# Altium schematic sheet Sheet_2_Power.SchDoc(Sheet_2_Power)
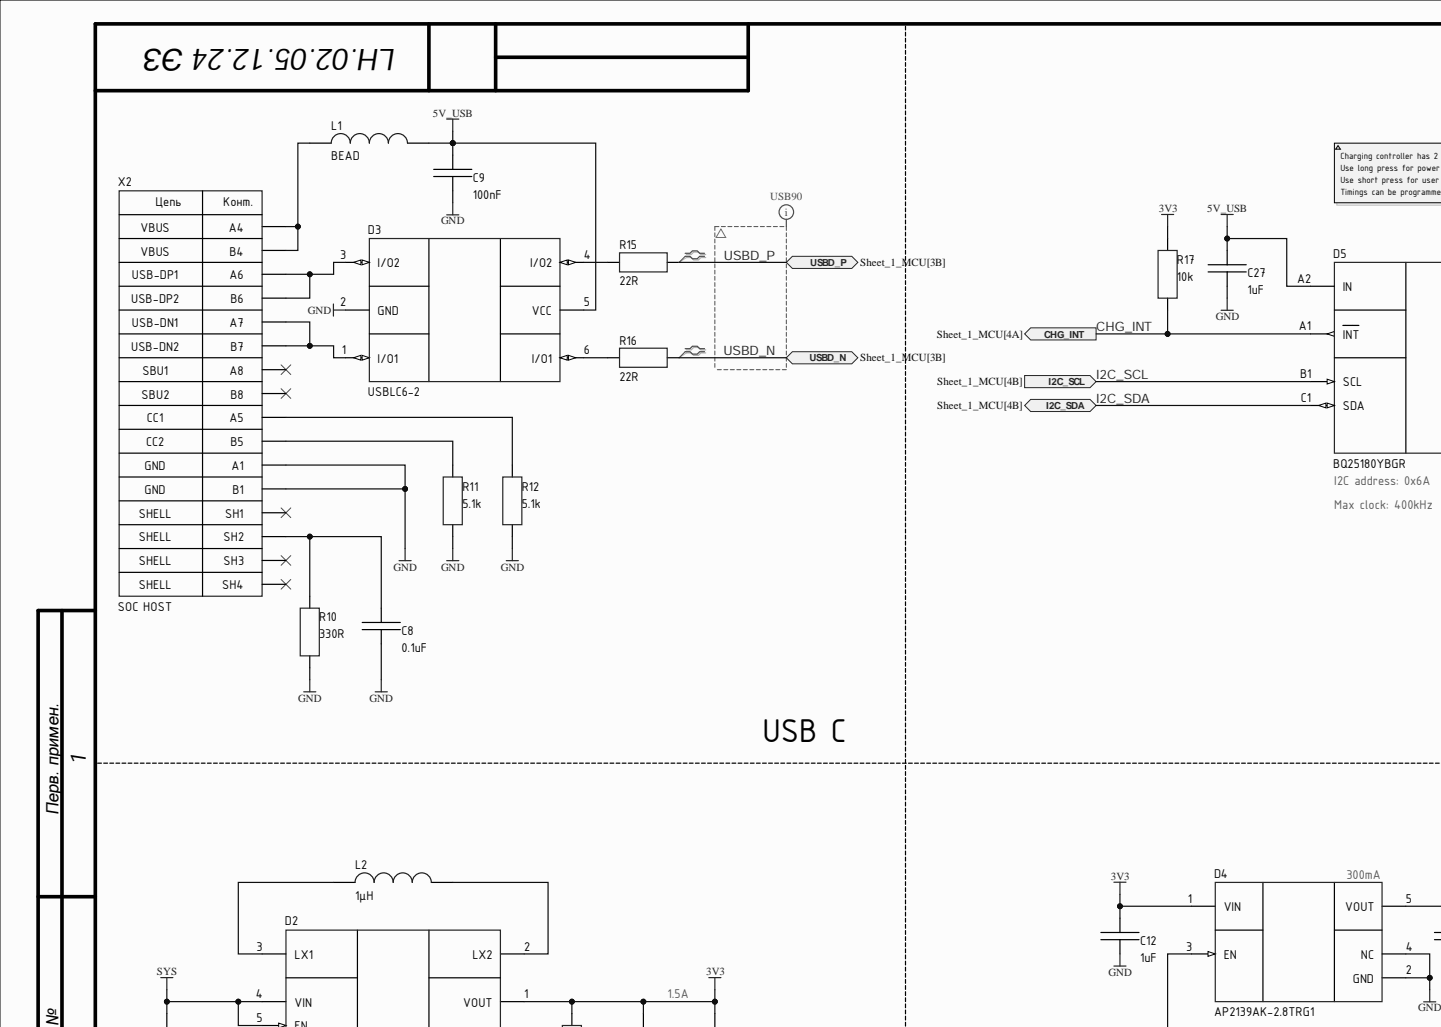
[diagram p0: Sheet_2_Power.SchDoc, top left]
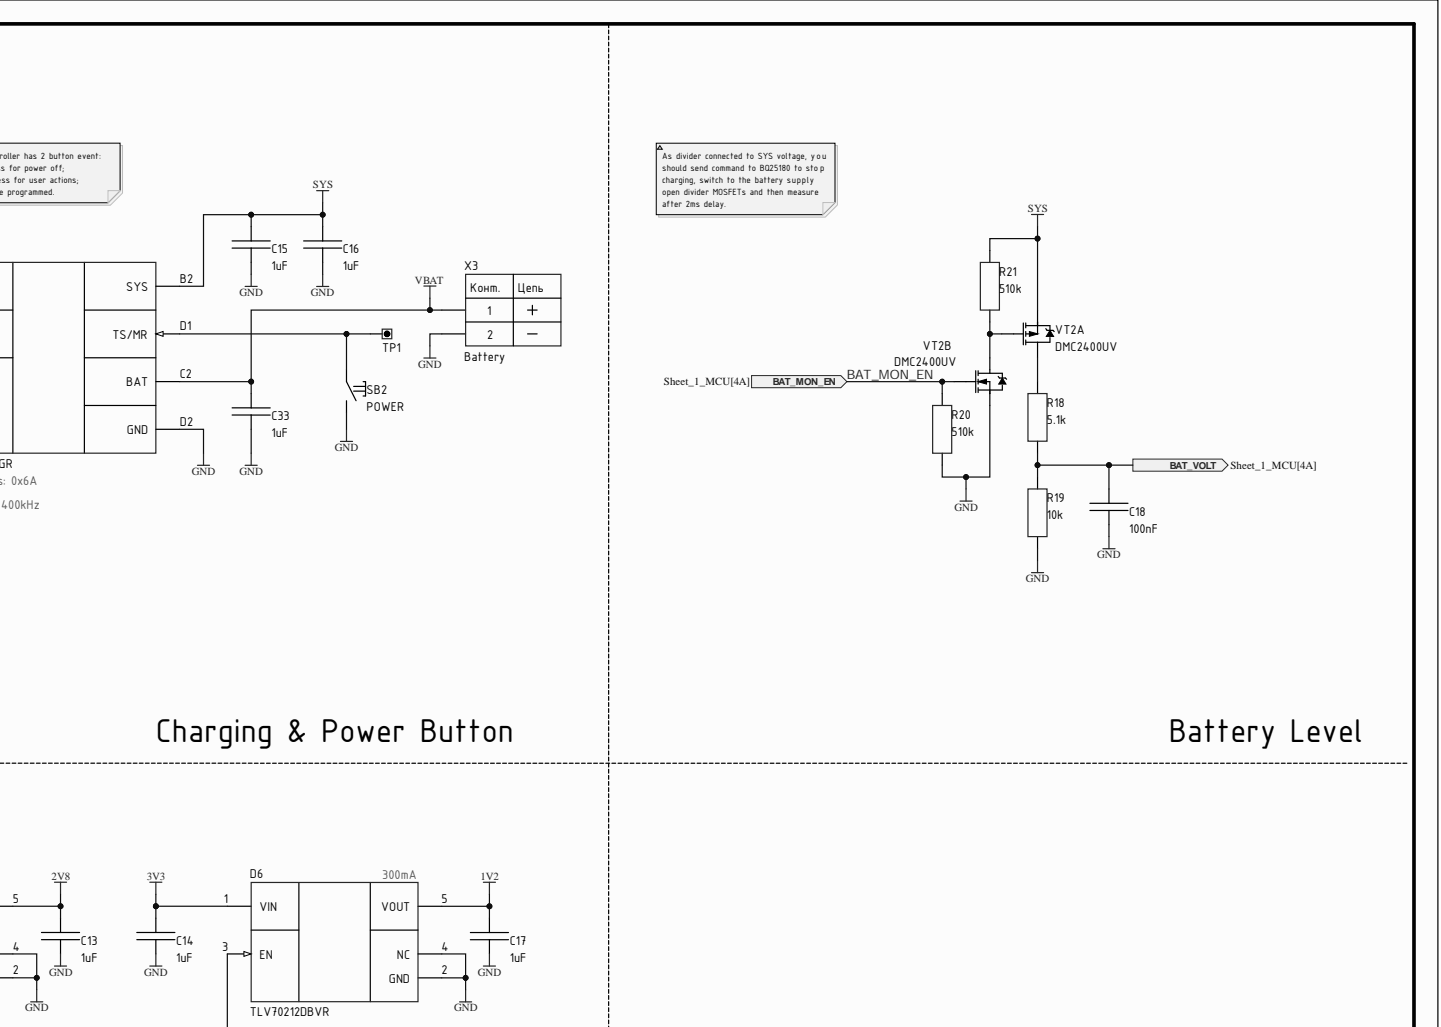
[diagram p1: Sheet_2_Power.SchDoc, top right]
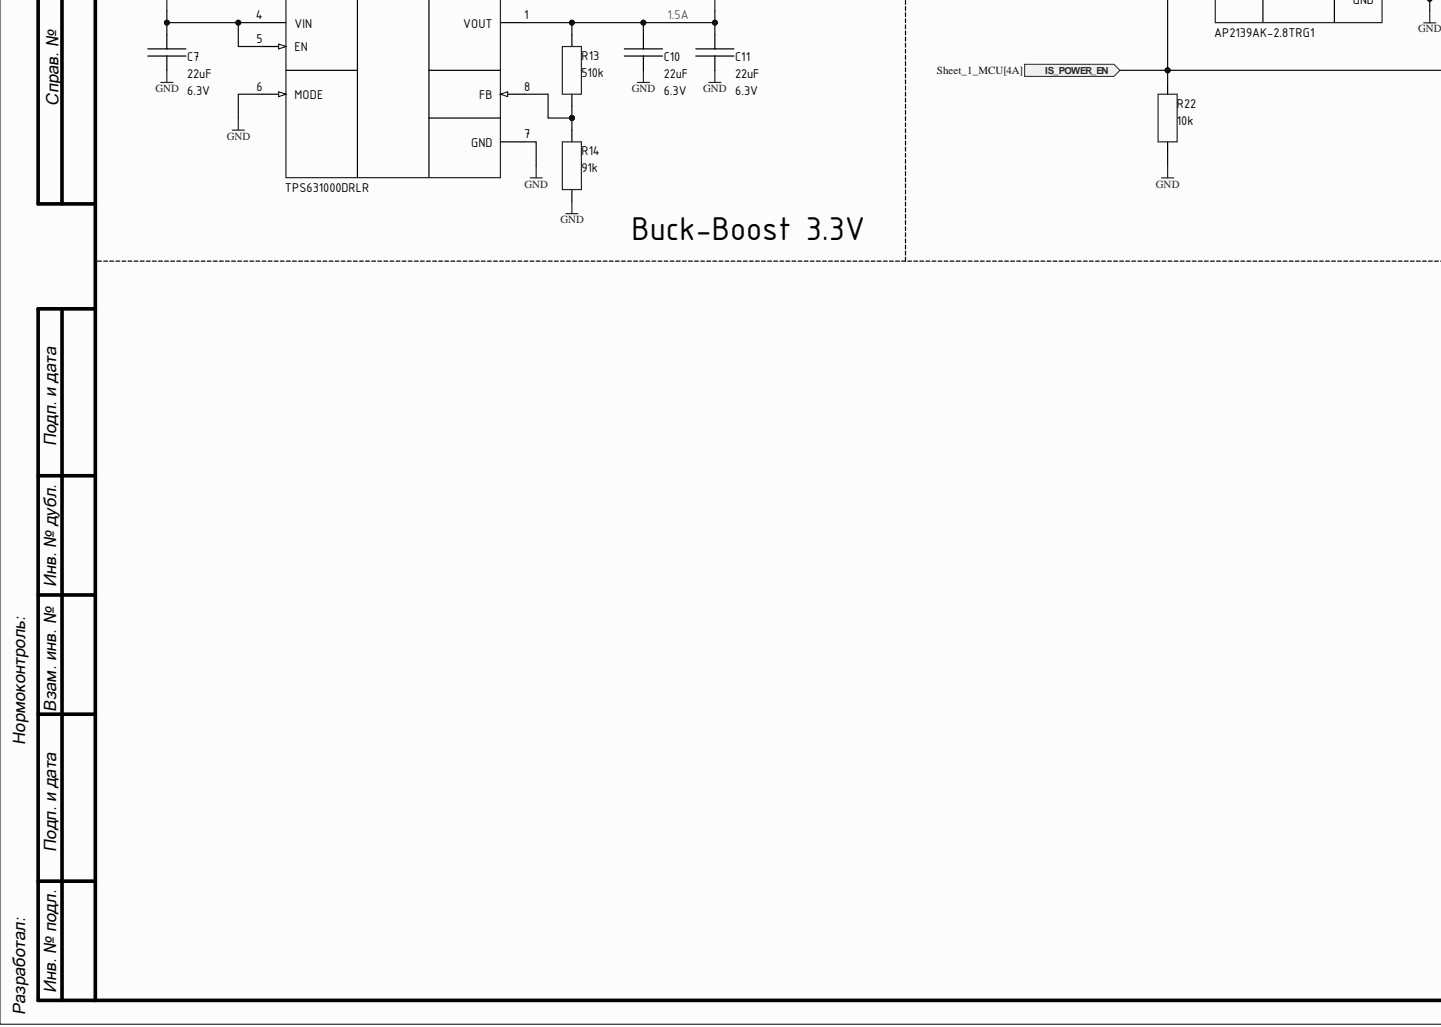
[diagram p2: Sheet_2_Power.SchDoc, bottom left]
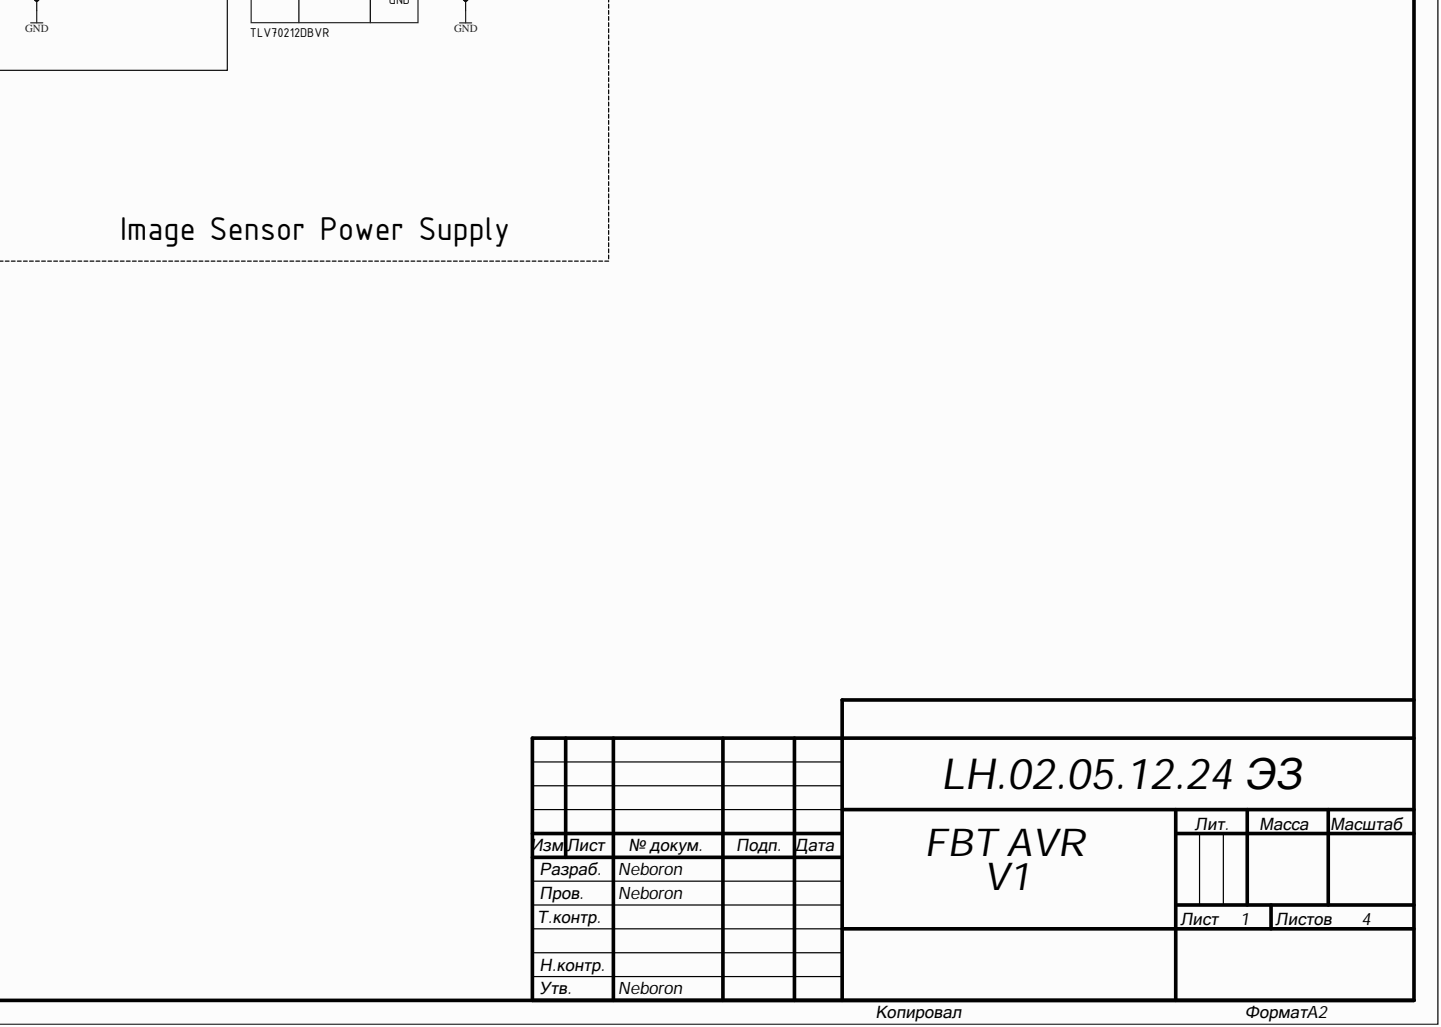
[diagram p3: Sheet_2_Power.SchDoc, bottom right]

## Parts
C7  value 22uF  Voltage: 6.3V  [CAP: 0603]  (hidden comment: Ceramic capacitor, 22uF, X5R, ±10%, 6.3V, 0603, -55...+125°C)
C8  value 0.1uF  [CAP: 0603]  (hidden comment: Ceramic capacitor, 0.1uF, X7R, ±10%, 16V, 0603, -55...+125°C)
C9  value 100nF  [CAP: 0402]  (hidden comment: Ceramic capacitor, 100nF, X7R, ±10%, 16V, 0402, -55...+125°C)
C10  value 22uF  Voltage: 6.3V  [CAP: 0603]  (hidden comment: Ceramic capacitor, 22uF, X5R, ±10%, 6.3V, 0603, -55...+125°C)
C11  value 22uF  Voltage: 6.3V  [CAP: 0603]  (hidden comment: Ceramic capacitor, 22uF, X5R, ±10%, 6.3V, 0603, -55...+125°C)
C12  value 1uF  [CAP: 0402]  (hidden comment: Ceramic capacitor, 1uF, X7R, ±10%, 16V, 0402, -55...+125°C)
C13  value 1uF  [CAP: 0402]  (hidden comment: Ceramic capacitor, 1uF, X7R, ±10%, 16V, 0402, -55...+125°C)
C14  value 1uF  [CAP: 0402]  (hidden comment: Ceramic capacitor, 1uF, X7R, ±10%, 16V, 0402, -55...+125°C)
C15  value 1uF  [CAP: 0402]  (hidden comment: Ceramic capacitor, 1uF, X7R, ±10%, 16V, 0402, -55...+125°C)
C16  value 1uF  [CAP: 0402]  (hidden comment: Ceramic capacitor, 1uF, X7R, ±10%, 16V, 0402, -55...+125°C)
C17  value 1uF  [CAP: 0402]  (hidden comment: Ceramic capacitor, 1uF, X7R, ±10%, 16V, 0402, -55...+125°C)
C18  value 100nF  [CAP: 0402]  (hidden comment: Ceramic capacitor, 100nF, X7R, ±10%, 16V, 0402, -55...+125°C)
C27  value 1uF  [CAP: 0402]  (hidden comment: Ceramic capacitor, 1uF, X7R, ±10%, 16V, 0402, -55...+125°C)
C33  value 1uF  [CAP: 0402]  (hidden comment: Ceramic capacitor, 1uF, X7R, ±10%, 16V, 0402, -55...+125°C)
D2  DC/DC buck-boost converter, 1.6...5.5V, 2.4MHz, 1.2...5.3V, 1.5A, -40...+125°C, SOT-583  [SOT583-8]  (value not drawn)
D3  ESD protection, , 8kV, , -40...+125°C, SOT23-6L  [SOT23-6]  (value not drawn)
D4  Linear voltage regulator, 2.9...6V, 0.5V, 1.5V, 0.25A, -40...+85°C, SOT23-5  [SOT23-5]  (value not drawn)
D5  Charger li-ion battery, input 4.35...26V, , 1A, -40...+125°C, 8-DSBGA  [8-DSBGA]  (value not drawn)
D6  Linear voltage regulator, 2...5.5V, 0.26V, 1.2V, 0.3A, -40...+85°C, SOT23-5  [SOT23-5]  (value not drawn)
L1  value BEAD  [IND: 0402]  (hidden comment: Fixed inductor, BEAD, ±25%, 0402, 900mA, -55...+125°C)
L2  value 1μH  [IND: 0805]  (hidden comment: Fixed inductor, 1μH, ±20%, 0805, 2.2A, -55...+125°C)
R10  value 330R  [RES: 0603]  (hidden comment: Resistor film, 330R, ±5%, 0603, 0.1W, -55...+125°C)
R11  value 5.1k  [RES: 0402]  (hidden comment: Resistor film, 5.1k, ±5%, 0402, 0.063W, -55...+125°C)
R12  value 5.1k  [RES: 0402]  (hidden comment: Resistor film, 5.1k, ±5%, 0402, 0.063W, -55...+125°C)
R13  value 510k  [RES: 0402]  (hidden comment: Resistor film, 510k, ±5%, 0402, 0.063W, -55...+125°C)
R14  value 91k  [RES: 0402]  (hidden comment: Resistor film, 91k, ±5%, 0402, 0.063W, -55...+125°C)
R15  value 22R  [RES: 0402]  (hidden comment: Resistor film, 22R, ±5%, 0402, 0.063W, -55...+125°C)
R16  value 22R  [RES: 0402]  (hidden comment: Resistor film, 22R, ±5%, 0402, 0.063W, -55...+125°C)
R17  value 10k  [RES: 0402]  (hidden comment: Resistor film, 10k, ±5%, 0402, 0.063W, -55...+125°C)
R18  value 5.1k  [RES: 0402]  (hidden comment: Resistor film, 5.1k, ±5%, 0402, 0.063W, -55...+125°C)
R19  value 10k  [RES: 0402]  (hidden comment: Resistor film, 10k, ±5%, 0402, 0.063W, -55...+125°C)
R20  value 510k  [RES: 0402]  (hidden comment: Resistor film, 510k, ±5%, 0402, 0.063W, -55...+125°C)
R21  value 510k  [RES: 0402]  (hidden comment: Resistor film, 510k, ±5%, 0402, 0.063W, -55...+125°C)
R22  value 10k  [RES: 0402]  (hidden comment: Resistor film, 10k, ±5%, 0402, 0.063W, -55...+125°C)
SB2  CommentText: POWER  [BUT: TS-1186F-B-A]  (hidden comment: Tactile button, TS-1186F)
TP1  Test point, Keystone, 5001  [CON: TEST POINT 1 MM]  (value not drawn)
VT2  PartNumber: DMC2400UV  [SOT563-6]  (hidden comment: MOSFET Dula-channel transistor, 20V, 700mA, N-70pC, P-850pC, 1W, N-480mOhm, P-970mOhm, N-750pF, P-1000pF, SOT563, -55...+150°C)  (parts A,B)
X2  CommentText: SOC HOST  [CON: TYPE-C-SOFNG MC-311S]  (hidden comment: USB Type C, 5A)
X3  CommentText: Battery  [CON: BAT (2.00)]  (hidden comment: Connector, 2pin, 2mm, ,)

## Ports
BAT_MON_EN, BAT_VOLT, CHG_INT, I2C_SCL, I2C_SDA, IS_POWER_EN, USBD_N, USBD_P

## Nets
1V2: C17.1 D6.5(VOUT)
2V8: C13.1 D4.5(VOUT)
3V3: C10.2 C11.2 C12.1 C14.1 D2.1(VOUT) D4.1(VIN) D6.1(VIN) R13.2 R17.1
5V_USB: C9.1 C27.1 D3.5(VCC) D5.A2(IN) L1.1
GND: C7.1 C8.2 C9.2 C10.1 C11.1 C12.2 C13.2 C14.2 C15.2 C16.2 C17.2 C18.1 C27.2 C33.2 D2.6(MODE) D2.7(GND) D3.2(GND) D4.2(GND) D4.4(NC) D5.D2(GND) D6.2(GND) D6.4(NC) R10.1 R11.1 R12.1 R14.1 R19.1 R20.1 R22.2 SB2.2 VT2.1 X2.A1 X2.B1 X3.2
SYS: C7.2 C15.1 C16.1 D2.4(VIN) D2.5(EN) D5.B2(SYS) R21.2 VT2.4
VBAT: C33.1 D5.C2(BAT) X3.1
BAT_MON_EN: R20.2 VT2.2 ; port BAT_MON_EN
BAT_VOLT: C18.2 R18.1 R19.2 ; port BAT_VOLT
CHG_INT: D5.A1(INT) R17.2 ; port CHG_INT
I2C_SCL: D5.B1(SCL) ; port I2C_SCL
I2C_SDA: D5.C1(SDA) ; port I2C_SDA
IS_POWER_EN: D4.3(EN) D6.3(EN) R22.1 ; port IS_POWER_EN
USBD_N: R16.1 ; port USBD_N
USBD_P: R15.1 ; port USBD_P
NetC8_1: C8.1 R10.2 X2.SH2
NetD2_2: D2.2(LX2) L2.1
NetD2_3: D2.3(LX1) L2.2
NetD2_8: D2.8(FB) R13.1 R14.2
NetD3_1: D3.1(I/O1) X2.A7 X2.B7
NetD3_3: D3.3(I/O2) X2.A6 X2.B6
NetD3_4: D3.4(I/O2) R15.2
NetD3_6: D3.6(I/O1) R16.2
NetD5_D1: D5.D1(TS/MR) SB2.1 TP1.1
NetL1_2: L1.2 X2.A4 X2.B4
NetR11_2: R11.2 X2.B5
NetR12_2: R12.2 X2.A5
NetR18_2: R18.2 VT2.3
NetR21_1: R21.1 VT2.5 VT2.6

Not connected: X2 A8 B8 SH1 SH3 SH4

## Library data of the parts
C7 C8 C10 C11 = Ceramic_0603: Manufacturer: Any; Component Kind: Standard; Library Name: capacitor.IntLib; Package: 0603; Temperature: -55...+125°C; Tolerance: ±10%; TypeCapacitor: Ceramic capacitor
  C7 C10 C11: TypeDielectric: X5R; ValueManufacturerPartNumber: Ceramic capacitor, 22uF, X5R, ±10%, 6.3V, 0603, -55...+125°C
  C8: TypeDielectric: X7R; ValueManufacturerPartNumber: Ceramic capacitor, 0.1uF, X7R, ±10%, 16V, 0603, -55...+125°C; Voltage: 16V
C9 C12-C18 C27 C33 = Ceramic_0402: Manufacturer: Any; Component Kind: Standard; Library Name: capacitor.IntLib; Package: 0402; Temperature: -55...+125°C; Tolerance: ±10%; TypeCapacitor: Ceramic capacitor; TypeDielectric: X7R; Voltage: 16V
  C9 C18: ValueManufacturerPartNumber: Ceramic capacitor, 100nF, X7R, ±10%, 16V, 0402, -55...+125°C
  C12-C17 C27 C33: ValueManufacturerPartNumber: Ceramic capacitor, 1uF, X7R, ±10%, 16V, 0402, -55...+125°C
D2 = TPS631000DRLR: Buck-boost converter; Manufacturer: Texas Instruments; Component Kind: Standard; ComponentType: DC/DC buck-boost converter; CurrentOutput: 1.5A; Frequency: 2.4MHz; HelpURL: https://www.ti.com/lit/ds/symlink/tps631000.pdf?ts=1735302154310&ref_url=https%253A%252F%252Fwww.ti.com%252Fproduct%252FTPS631000; Library Name: ic-power-supply.IntLib; Package: SOT-583; PartNumber: TPS631000DRLR; Temperature: -40...+125°C; ValueManufacturerPartNumber: DC/DC buck-boost converter, 1.6...5.5V, 2.4MHz, 1.2...5.3V, 1.5A, -40...+125°C, SOT-583; VoltageInput: 1.6...5.5V; VoltageOutput: 1.2...5.3V
D3 = USBLC6-2: ESD protection; Manufacturer: STMicroelectronics; Component Kind: Standard; ComponentType: ESD protection; HelpURL: https://www.st.com/resource/en/datasheet/usblc6-2.pdf; Isolation: 8kV; Library Name: ic-interface.IntLib; Package: SOT23-6L; PartNumber: USBLC6-2; Temperature: -40...+125°C; ValueManufacturerPartNumber: ESD protection, , 8kV, , -40...+125°C, SOT23-6L
D4 = AP2139AK-2.8TRG1: Linear voltage regulator 2.8V; Manufacturer: Diodes Incorporated; Component Kind: Standard; ComponentType: Linear voltage regulator; CurrentOutput: 0.25A; HelpURL: https://www.lcsc.com/datasheet/lcsc_datasheet_2304140030_Diodes-Incorporated-AP2139AK-1-5TRG1_C460336.pdf; Library Name: ic-power-supply.IntLib; MaxDropout: 0.5V; Package: SOT23-5; PartNumber: AP2139AK-2.8TRG1; Temperature: -40...+85°C; ValueManufacturerPartNumber: Linear voltage regulator, 2.9...6V, 0.5V, 1.5V, 0.25A, -40...+85°C, SOT23-5; VoltageInput: 2.9...6V; VoltageOutput: 1.5V
D5 = BQ25180YBGR: Charger; Manufacturer: Texas Instruments; Component Kind: Standard; ComponentType: Charger li-ion battery; CurrentOutput: 1A; HelpURL: https://www.ti.com/lit/ds/symlink/bq25180.pdf?ts=1686486458082&ref_url=https%253A%252F%252Fwww.ti.com%252Fpower-management%252Fbattery-management%252Fcharger-ics%252Fproducts.html; Library Name: ic-power-supply.IntLib; Package: 8-DSBGA; PartNumber: BQ25180YBGR; Temperature: -40...+125°C; ValueManufacturerPartNumber: Charger li-ion battery, input 4.35...26V, , 1A, -40...+125°C, 8-DSBGA; VoltageInput: input 4.35...26V
D6 = TLV70212DBVR: Linear voltage regulator 1.2V; Manufacturer: Texas Instruments; Component Kind: Standard; ComponentType: Linear voltage regulator; CurrentOutput: 0.3A; HelpURL: https://www.lcsc.com/datasheet/lcsc_datasheet_1810121324_Texas-Instruments-TLV70212DBVR_C81462.pdf; Library Name: ic-power-supply.IntLib; MaxDropout: 0.26V; Package: SOT23-5; PartNumber: TLV70212DBVR; Temperature: -40...+85°C; ValueManufacturerPartNumber: Linear voltage regulator, 2...5.5V, 0.26V, 1.2V, 0.3A, -40...+85°C, SOT23-5; VoltageInput: 2...5.5V; VoltageOutput: 1.2V
L1 = Inductor_0402: Manufacturer: Murata Electronics; PartNumber: BLM15PX601SN1D; Component Kind: Standard; HelpURL: https://www.lcsc.com/datasheet/lcsc_datasheet_2304140030_Murata-Electronics-BLM15PX601SN1D_C160977.pdf; Library Name: inductor.IntLib; MaxCurrent: 900mA; Package: 0402; Temperature: -55...+125°C; Tolerance: ±25%; TypeInductor: Fixed inductor; ValueManufacturerPartNumber: Fixed inductor, BEAD, ±25%, 0402, 900mA, -55...+125°C
L2 = Inductor_0805: Manufacturer: Taiyo Yuden; PartNumber: MAKK2016T1R0M; Component Kind: Standard; HelpURL: https://www.mouser.ee/datasheet/2/396/wound10_e-1396630.pdf; Library Name: inductor.IntLib; MaxCurrent: 2.2A; Package: 0805; Temperature: -55...+125°C; Tolerance: ±20%; TypeInductor: Fixed inductor; ValueManufacturerPartNumber: Fixed inductor, 1μH, ±20%, 0805, 2.2A, -55...+125°C
R10 = Resistor_0603: Manufacturer: Any; Component Kind: Standard; Library Name: resistor.IntLib; Package: 0603; Power: 0.1W; Temperature: -55...+125°C; Tolerance: ±5%; TypeResistor: Resistor film; ValueManufacturerPartNumber: Resistor film, 330R, ±5%, 0603, 0.1W, -55...+125°C
R11-R22 = Resistor_0402: Manufacturer: Any; Component Kind: Standard; Library Name: resistor.IntLib; Package: 0402; Power: 0.063W; Temperature: -55...+125°C; Tolerance: ±5%; TypeResistor: Resistor film
  R11 R12 R18: ValueManufacturerPartNumber: Resistor film, 5.1k, ±5%, 0402, 0.063W, -55...+125°C
  R13 R20 R21: ValueManufacturerPartNumber: Resistor film, 510k, ±5%, 0402, 0.063W, -55...+125°C
  R14: ValueManufacturerPartNumber: Resistor film, 91k, ±5%, 0402, 0.063W, -55...+125°C
  R15 R16: ValueManufacturerPartNumber: Resistor film, 22R, ±5%, 0402, 0.063W, -55...+125°C
  R17 R19 R22: ValueManufacturerPartNumber: Resistor film, 10k, ±5%, 0402, 0.063W, -55...+125°C
SB2 = Button_tactile: Manufacturer: XKB Connection; PartNumber: TS-1186F-C-A; Component Kind: Standard; HelpURL: https://www.lcsc.com/product-detail/Tactile-Switches_XKB-Connection-TS-1186F-C-A_C908471.html; Library Name: electromechanics.IntLib; Package: TS-1186F; TypeButton: Tactile button; ValueManufacturerPartNumber: Tactile button, TS-1186F
TP1 = Connector_Test_Point: PartNumber: 5001; Component Kind: Standard; HelpURL: https://www.digikey.com/product-detail/en/keystone-electronics/5001/36-5001-ND/255327; Library Name: connector.IntLib; Manufacturer: Keystone; TypeConnector: Test point; ValueManufacturerPartNumber: Test point, Keystone, 5001
VT2 = MOSFET_DUAL_NP_ISO: Manufacturer: Diodes Incorporated; Component Kind: Standard; CurrentDS: 700mA; GateCharge: N-70pC, P-850pC; HelpURL: https://www.mouser.ee/datasheet/2/115/DIOD_S_A0010038249_1-2543538.pdf; InputCapacitor: N-750pF, P-1000pF; Library Name: transistor.IntLib; MaxPower: 1W; Package: SOT563; RDS: N-480mOhm, P-970mOhm; Temperature: -55...+150°C; TypeTransistor: MOSFET Dula-channel transistor; ValueManufacturerPartNumber: MOSFET Dula-channel transistor, 20V, 700mA, N-70pC, P-850pC, 1W, N-480mOhm, P-970mOhm, N-750pF, P-1000pF, SOT563, -55...+150°C; VoltageDS: 20V
X2 = Connector_USB_Type_C: Manufacturer: GCT; PartNumber: TYPEC-304YSSW-ACP16; Component Kind: Standard; CurrentMax: 5A; HelpURL: https://www.mouser.ee/datasheet/2/837/GCT_USB4110_Product_Drawing___20k_cycles-3455479.pdf; Library Name: connector.IntLib; TypeConnector: USB Type C; ValueManufacturerPartNumber: USB Type C, 5A
X3 = Connector_BAT-2: Component Kind: Standard; HelpURL: https://www.chipdip.ru/product/cwf-2r-ds1069-2-mr; Library Name: connector.IntLib; Multichannel: 2pin; Pitch: 2mm; TypeConnector: Connector; ValueManufacturerPartNumber: Connector, 2pin, 2mm, ,
